# Altium schematic sheet Ethernet.SchDoc
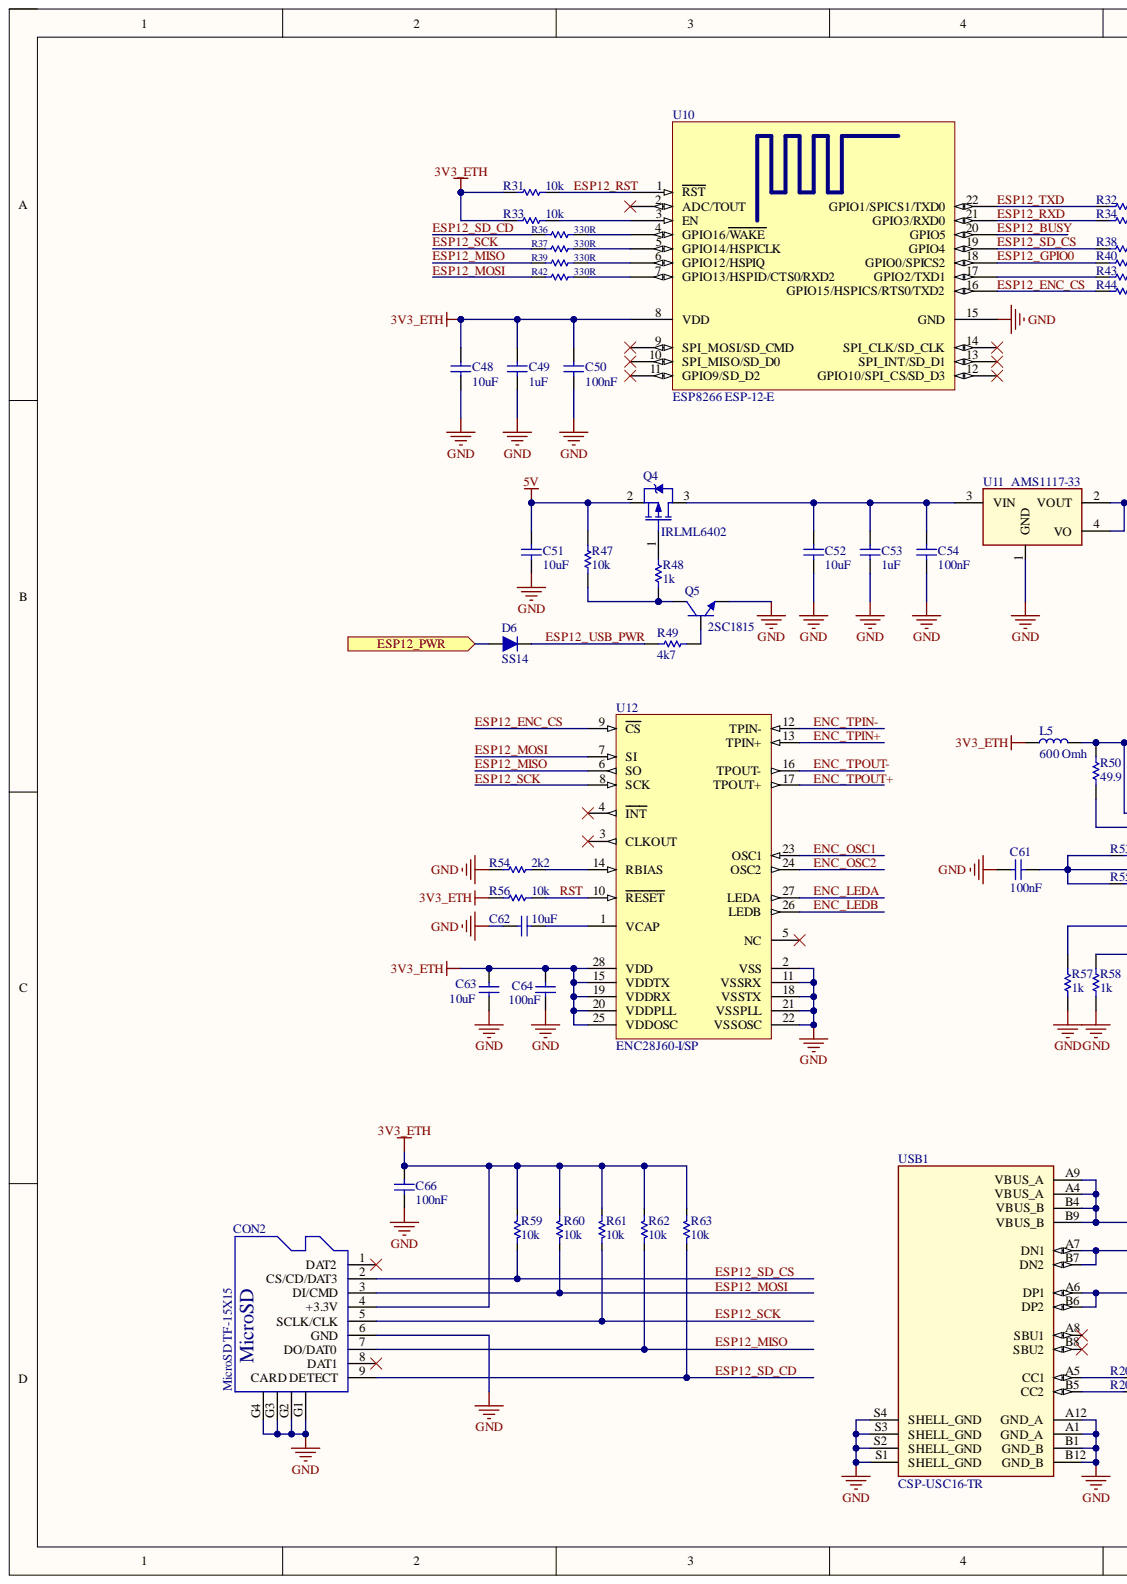
[diagram p0: Ethernet.SchDoc, left]
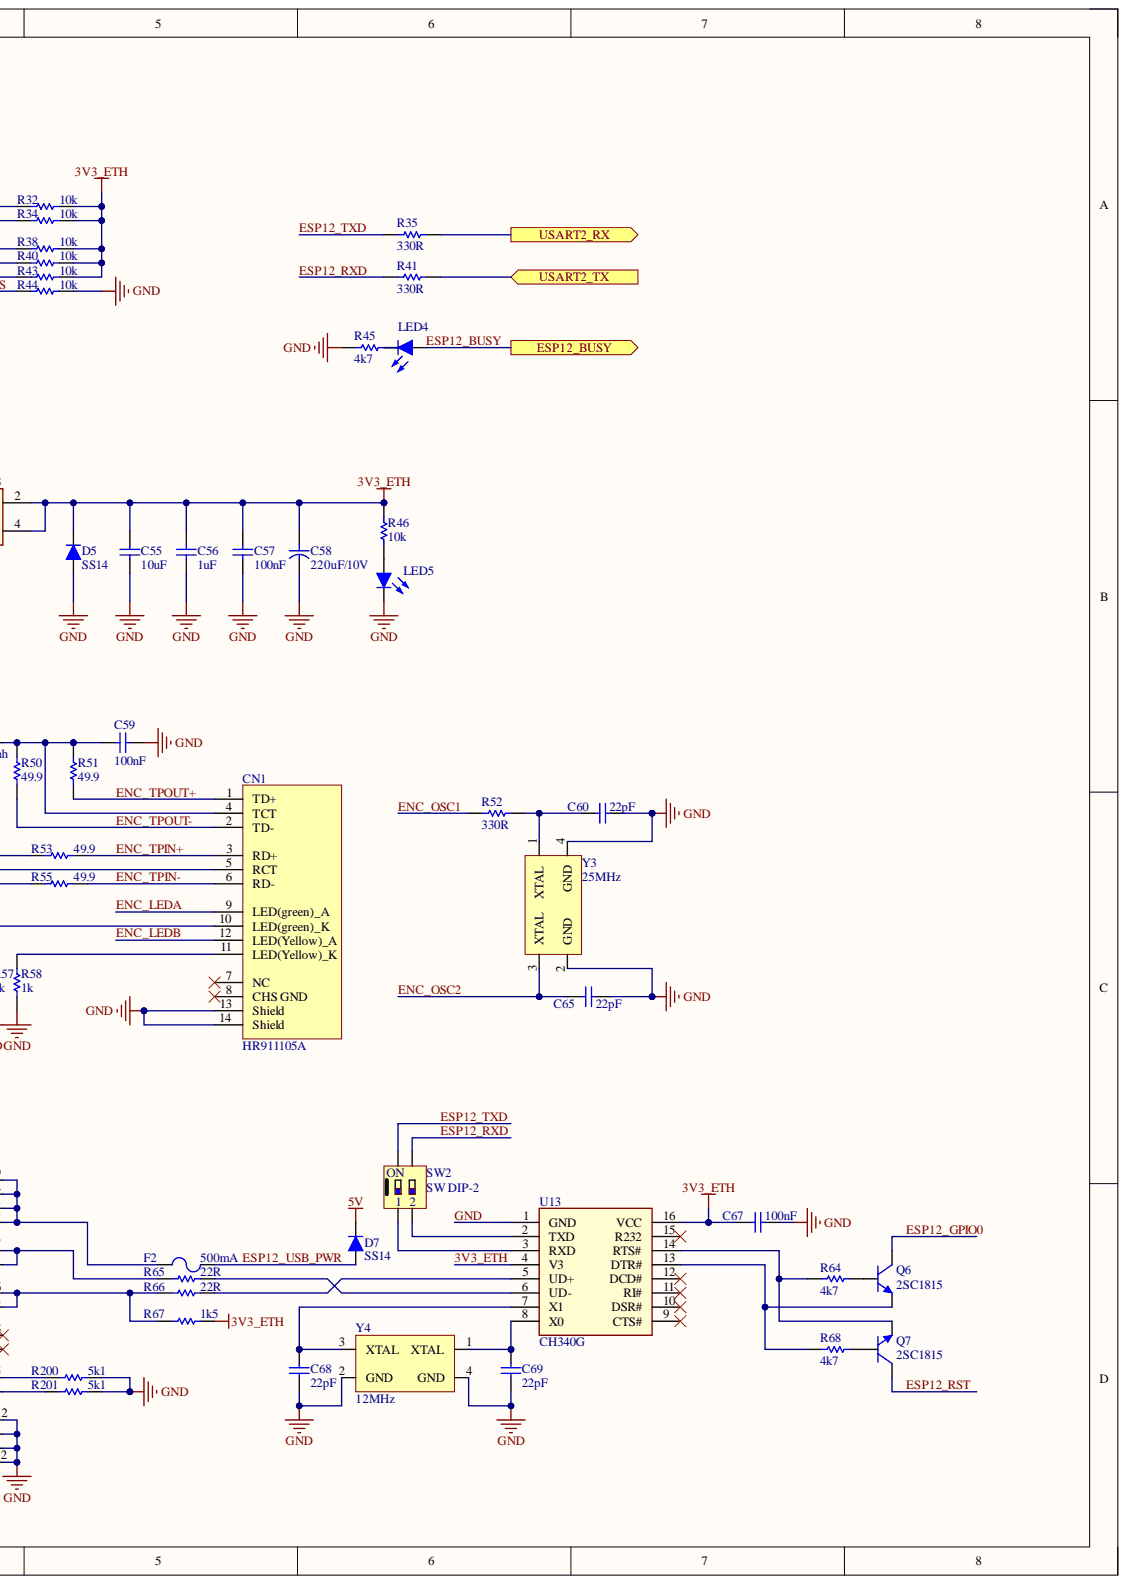
[diagram p1: Ethernet.SchDoc, right]

## Parts
C48  10uF  [C 0603 (1608)]
C49  1uF  [C 0603 (1608)]
C50  100nF  [C 0603 (1608)]
C51  10uF  [C 0603 (1608)]
C52  10uF  [C 0603 (1608)]
C53  1uF  [C 0603 (1608)]
C54  100nF  [C 0603 (1608)]
C55  10uF  [C 0603 (1608)]
C56  1uF  [C 0603 (1608)]
C57  100nF  [C 0603 (1608)]
C58  220uF/10V  [C SMD ALU (D)]
C59  100nF  [C 0603 (1608)]
C60  22pF  [C 0603 (1608)]
C61  100nF  [C 0603 (1608)]
C62  10uF  [C 0603 (1608)]
C63  100nF  [C 0603 (1608)]
C64  10uF  [C 0603 (1608)]  (value not drawn)
C65  22pF  [C 0603 (1608)]
C66  100nF  [C 0603 (1608)]
C67  100nF  [C 0603 (1608)]
C68  22pF  [C 0603 (1608)]
C69  22pF  [C 0603 (1608)]
CN1  HR911105A  [CON RJ45 HR911105A]
CON2  MicroSD TF-15X15  [CON SD Micro TF-15X15]
D5  SS14  [DO-214AC (SMA)]
D6  SS14  [DO-214AC (SMA)]
D7  SS14  [DO-214AC (SMA)]
F2  500mA  [Fuse 0805 SMD]
L5  600 Omh  [L 0603 (1608)]
LED4  Led-Blue  [Led SMD 0603 BLUE]  (value not drawn)
LED5  Led-Red  [Led SMD 0603 RED]  (value not drawn)
Q4  IRLML6402  [SOT-23]
Q5  2SC1815  [SOT-23]
Q6  2SC1815  [SOT-23]
Q7  2SC1815  [SOT-23]
R31  10k  [R 0603 (1608)]
R32  10k  [R 0603 (1608)]
R33  10k  [R 0603 (1608)]
R34  10k  [R 0603 (1608)]
R35  330R  [R 0603 (1608)]
R36  330R  [R 0603 (1608)]
R37  330R  [R 0603 (1608)]
R38  10k  [R 0603 (1608)]
R39  330R  [R 0603 (1608)]
R40  10k  [R 0603 (1608)]
R41  330R  [R 0603 (1608)]
R42  330R  [R 0603 (1608)]
R43  10k  [R 0603 (1608)]
R44  10k  [R 0603 (1608)]
R45  4k7  [R 0603 (1608)]
R46  10k  [R 0603 (1608)]
R47  10k  [R 0603 (1608)]
R48  1k  [R 0603 (1608)]
R49  4k7  [R 0603 (1608)]
R50  49.9  [R 0603 (1608)]
R51  49.9  [R 0603 (1608)]
R52  330R  [R 0603 (1608)]
R53  49.9  [R 0603 (1608)]
R54  2k2  [R 0603 (1608)]
R55  49.9  [R 0603 (1608)]
R56  10k  [R 0603 (1608)]
R57  1k  [R 0603 (1608)]
R58  1k  [R 0603 (1608)]
R59  10k  [R 0603 (1608)]
R60  10k  [R 0603 (1608)]
R61  10k  [R 0603 (1608)]
R62  10k  [R 0603 (1608)]
R63  10k  [R 0603 (1608)]
R64  4k7  [R 0603 (1608)]
R65  22R  [R 0603 (1608)]
R66  22R  [R 0603 (1608)]
R67  1k5  [R 0603 (1608)]
R68  4k7  [R 0603 (1608)]
R200  5k1  [R 0603 (1608)]
R201  5k1  [R 0603 (1608)]
SW2  SW DIP-2  [SW/DIP2]
U10  ESP8266 ESP-12-E  [ESP8266 ESP-12-E]
U11  AMS1117-33  [SOT-223]
U12  ENC28J60-I/SP  [SOIC-28-WIDE]
U13  CH340G  [SOIC-16]
USB1  CSP-USC16-TR  [CSP-USC16-TR]
Y3  25MHz  [Crystal SMD 4PIN 3225]
Y4  12MHz  [Crystal SMD 4PIN 3225]

## Ports
ESP12_BUSY, ESP12_PWR, USART2_RX, USART2_TX

## Nets
5V: C51.1 D7.2 Q4.2 R47.2
3V3_ETH: C48.1 C49.1 C50.1 C55.1 C56.1 C57.1 C58.1 C63.1 C64.1 C66.2 C67.2 CON2.4(+3.3V) D5.2 L5.1 R31.1 R32.1 R33.2 R34.1 R38.1 R40.1 R43.1 R46.2 R56.2 R59.1 R60.1 R61.1 R62.1 R63.1 R67.2 U10.8(VDD) U11.2(VOUT) U11.4(VO) U12.15(VDDTX) U12.19(VDDRX) U12.20(VDDPLL) U12.25(VDDOSC) U12.28(VDD) U13.4(V3) U13.16(VCC)
ENC_LEDA: CN1.9(LED(green)_A) U12.27(LEDA)
ENC_LEDB: CN1.12(LED(Yellow)_A) U12.26(LEDB)
ENC_OSC1: R52.2 U12.23(OSC1)
ENC_OSC2: C65.1 U12.24(OSC2) Y3.3(XTAL)
ENC_TPIN+: CN1.3(RD+) R53.1 U12.13(TPIN+)
ENC_TPIN-: CN1.6(RD-) R55.1 U12.12(TPIN-)
ENC_TPOUT+: CN1.1(TD+) R51.1 U12.17(TPOUT+)
ENC_TPOUT-: CN1.2(TD-) R50.1 U12.16(TPOUT-)
ESP12_BUSY: LED4.1 U10.20(GPIO5) ; port ESP12_BUSY
ESP12_ENC_CS: R44.2 U10.16(GPIO15/HSPICS/RTS0/TXD2) U12.9(CS)
ESP12_GPIO0: Q6.3 R40.2 U10.18(GPIO0/SPICS2)
ESP12_MISO: CON2.7(DO/DAT0) R39.2 R62.2 U12.6(SO)
ESP12_MOSI: CON2.3(DI/CMD) R42.2 R60.2 U12.7(SI)
ESP12_PWR: D6.1 ; port ESP12_PWR
ESP12_RST: Q7.3 R31.2 U10.1(RST)
ESP12_RXD: R34.2 R41.2 SW2.3 U10.21(GPIO3/RXD0)
ESP12_SCK: CON2.5(SCLK/CLK) R37.2 R61.2 U12.8(SCK)
ESP12_SD_CD: CON2.9(CARD_DETECT) R36.2 R63.2
ESP12_SD_CS: CON2.2(CS/CD/DAT3) R38.2 R59.2 U10.19(GPIO4)
ESP12_TXD: R32.2 R35.2 SW2.4(ON) U10.22(GPIO1/SPICS1/TXD0)
ESP12_USB_PWR: D6.2 D7.1 F2.2 R49.1
GND: C48.2 C49.2 C50.2 C51.2 C52.2 C53.2 C54.2 C55.2 C56.2 C57.2 C58.2 C59.2 C60.2 C61.1 C62.1 C63.2 C64.2 C65.2 C66.1 C67.1 C68.1 C69.1 CN1.13(Shield) CN1.14(Shield) CON2.6(GND) CON2.G1 CON2.G2 CON2.G3 CON2.G4 D5.1 LED5.2 Q5.2 R44.1 R45.1 R54.2 R57.2 R58.2 R200.2 R201.2 U10.15(GND) U11.1(GND) U12.2(VSS) U12.11(VSSRX) U12.18(VSSTX) U12.21(VSSPLL) U12.22(VSSOSC) U13.1(GND) USB1.A1(GND_A) USB1.A12(GND_A) USB1.B1(GND_B) USB1.B12(GND_B) USB1.S1(SHELL_GND) USB1.S2(SHELL_GND) USB1.S3(SHELL_GND) USB1.S4(SHELL_GND) Y3.2(GND) Y3.4(GND) Y4.2(GND) Y4.4(GND)
RST: R56.1 U12.10(RESET)
USART2_RX: R35.1 ; port USART2_RX
USART2_TX: R41.1 ; port USART2_TX
NetC52_1: C52.1 C53.1 C54.1 Q4.3 U11.3(VIN)
NetC59_1: C59.1 CN1.4(TCT) L5.2 R50.2 R51.2
NetC60_1: C60.1 R52.1 Y3.1(XTAL)
NetC61_2: C61.2 CN1.5(RCT) R53.2 R55.2
NetC62_2: C62.2 U12.1(VCAP)
NetC68_2: C68.2 U13.7(X1) Y4.3(XTAL)
NetC69_2: C69.2 U13.8(X0) Y4.1(XTAL)
NetCN1_10: CN1.10(LED(green)_K) R57.1
NetCN1_11: CN1.11(LED(Yellow)_K) R58.1
NetF2_1: F2.1 USB1.A4(VBUS_A) USB1.A9(VBUS_A) USB1.B4(VBUS_B) USB1.B9(VBUS_B)
NetLED4_2: LED4.2 R45.2
NetLED5_1: LED5.1 R46.1
NetQ4_1: Q4.1 R48.1
NetQ5_1: Q5.1 R49.2
NetQ5_3: Q5.3 R47.1 R48.2
NetQ6_1: Q6.1 R64.2
NetQ6_2: Q6.2 R68.1 U13.13(DTR#)
NetQ7_1: Q7.1 R68.2
NetQ7_2: Q7.2 R64.1 U13.14(RTS#)
NetR33_1: R33.1 U10.3(EN)
NetR36_1: R36.1 U10.4(GPIO16/WAKE)
NetR37_1: R37.1 U10.5(GPIO14/HSPICLK)
NetR39_1: R39.1 U10.6(GPIO12/HSPIQ)
NetR42_1: R42.1 U10.7(GPIO13/HSPID/CTS0/RXD2)
NetR43_2: R43.2 U10.17(GPIO2/TXD1)
NetR54_1: R54.1 U12.14(RBIAS)
NetR65_1: R65.1 USB1.A7(DN1) USB1.B7(DN2)
NetR65_2: R65.2 U13.6(UD-)
NetR66_1: R66.1 R67.1 USB1.A6(DP1) USB1.B6(DP2)
NetR66_2: R66.2 U13.5(UD+)
NetR200_1: R200.1 USB1.A5(CC1)
NetR201_1: R201.1 USB1.B5(CC2)
NetSW2_1: SW2.1 U13.3(RXD)
NetSW2_2: SW2.2 U13.2(TXD)

Not connected: CN1 7(NC) 8(CHS_GND); CON2 1(DAT2) 8(DAT1); U10 2(ADC/TOUT) 9(SPI_MOSI/SD_CMD) 10(SPI_MISO/SD_D0) 11(GPIO9/SD_D2) 12(GPIO10/SPI_CS/SD_D3) 13(SPI_INT/SD_D1) 14(SPI_CLK/SD_CLK); U12 3(CLKOUT) 4(INT) 5(NC); U13 9(CTS#) 10(DSR#) 11(RI#) 12(DCD#) 15(R232); USB1 A8(SBU1) B8(SBU2)

## Library data of the parts
C48-C57 C59-C69 = Capacitor NP: Capacitor non-pol; Component Kind: Standard
  C48-C57 C59-C65 C67-C69: Library Name: BKIT4U_LIB.SchLib
  C66: Library Name: BKIT4U_LIB_NEW.SchLib
C58 = Capacitor: Capacitor pol; Component Kind: Standard; Library Name: BKIT4U_LIB.SchLib
CN1 = CON RJ45 HR911105A: Connector RJ45 HR911105A; Component Kind: Standard; Library Name: BKIT4U_LIB.SchLib
CON2 = CON SDCard Micro: Component Kind: Standard; Description: MicroSD TF-15X15; Library Name: BKIT4U_LIB_NEW.SchLib
D5-D7 = Diode: Default Diode; Code_JEDEC: DO-214-AB; Component Kind: Standard; LatestRevisionDate: 17-Jul-2002; LatestRevisionNote: Re-released for DXP Platform.; Library Name: BKIT4U_LIB.SchLib; PackageReference: SMC; PackageVersion: Sep-1996; Published: 8-Jun-2000; Publisher: Altium Limited
F2 = Fuse: Component Kind: Standard; Description: Fuse; Library Name: BKIT4U_LIB.SchLib
L5 = Inductor: Component Kind: Standard; Description: Inductor; Library Name: BKIT4U_LIB.SchLib
LED4 LED5 = Led: Typical LED; Component Kind: Standard; Library Name: BKIT4U_LIB.SchLib
Q4 = MOSFET P-Channel: Component Kind: Standard; Description: MOSFET P-Channel; Library Name: BKIT4U_LIB.SchLib
Q5-Q7 = Transistor NPN: NPN Bipolar Transistor; Component Kind: Standard; Library Name: BKIT4U_LIB.SchLib
R31-R68 R200 R201 = Resistor: Component Kind: Standard; Description: Resistor; LatestRevisionDate: 17-Jul-2002; LatestRevisionNote: Re-released for DXP Platform.; PackageReference: AXIAL-0.3; Published: 8-Jun-2000; Publisher: Altium Limited
  R31-R58 R64-R68 R200 R201: Library Name: BKIT4U_LIB.SchLib
  R59-R63: Library Name: BKIT4U_LIB_NEW.SchLib
U11 = AMS1117: 1A LDO Voltage Regulator, ADJ or fixed 1.5/1.8/2.5/2.85/3.3/5V; Component Kind: Standard; Library Name: BKIT4U_LIB.SchLib
U12 = ENC28J60-I/SP: Stand-Alone Ethernet Controller with SPI Interface, 28-Pin SPDIP, Industrial Temperature; Manufacturer: Microchip; Component Kind: Standard; ComponentLink1Description: Manufacturer URL; ComponentLink1URL: http://www.microchip.com/; ComponentLink2Description: Datasheet; ComponentLink2URL: http://ww1.microchip.com/downloads/en/DeviceDoc/39662c.pdf; ComponentLink3Description: Package Specification; ComponentLink3URL: http://www.microchip.com/stellent/groups/techpub_sg/documents/packagingspec/en012702.pdf; DatasheetVersion: revC, Jan-2008; Library Name: Microchip Interface.IntLib; Mounting Technology: Through Hole; PackageDescription: 28-Lead Skinny Plastic Dual In-Line (SP) - 300 mil Body [SPDIP]; PackageReference: SPDIP300-SP28; PackageVersion: revBB, Aug-2009; PartNumber: ENC28J60-I/SP; Supplier 1: Digi-Key; Supplier 2: Farnell; Supplier 3: Newark; Supplier 4: Mouser; Supplier Part Number 1: ENC28J60-I/SP-ND; Supplier Part Number 2: 1564402; Supplier Part Number 3: 71J3845; Supplier Part Number 4: 579-ENC28J60-I/SP
U13 = CH340G: USB TO UART; Component Kind: Standard; Library Name: BKIT4U_LIB.SchLib
USB1 = CSP-USC16-TR: CSP-USC16-TR Valcon USB Type C Surface Mount PCB Socket; Availability: In Stock; Check_prices: https://www.snapeda.com/parts/CSP-USC16-TR/Valcon/view-part/?ref=eda; Component Kind: Standard; Library Name: BKIT4U_LIB.SchLib; MF: Valcon; MP: CSP-USC16-TR; Package: None; Price: None; Purchase-URL: https://pricing.snapeda.com/search/part/CSP-USC16-TR/?ref=eda; SnapEDA_Link: https://www.snapeda.com/parts/CSP-USC16-TR/Valcon/view-part/?ref=snap
Y3 Y4 = Crystal 4pin: Component Kind: Standard; Library Name: BKIT4U_LIB.SchLib
SW2 = SW DIP-2: Component Kind: Standard; Library Name: BKIT4U_LIB.SchLib
U10 = ESP8266 ESP-12-E: Component Kind: Standard; Description: ESP8266 ESP-12-E; Library Name: ESP8266.SchLib
